# Altium schematic sheet Memory.SchDoc
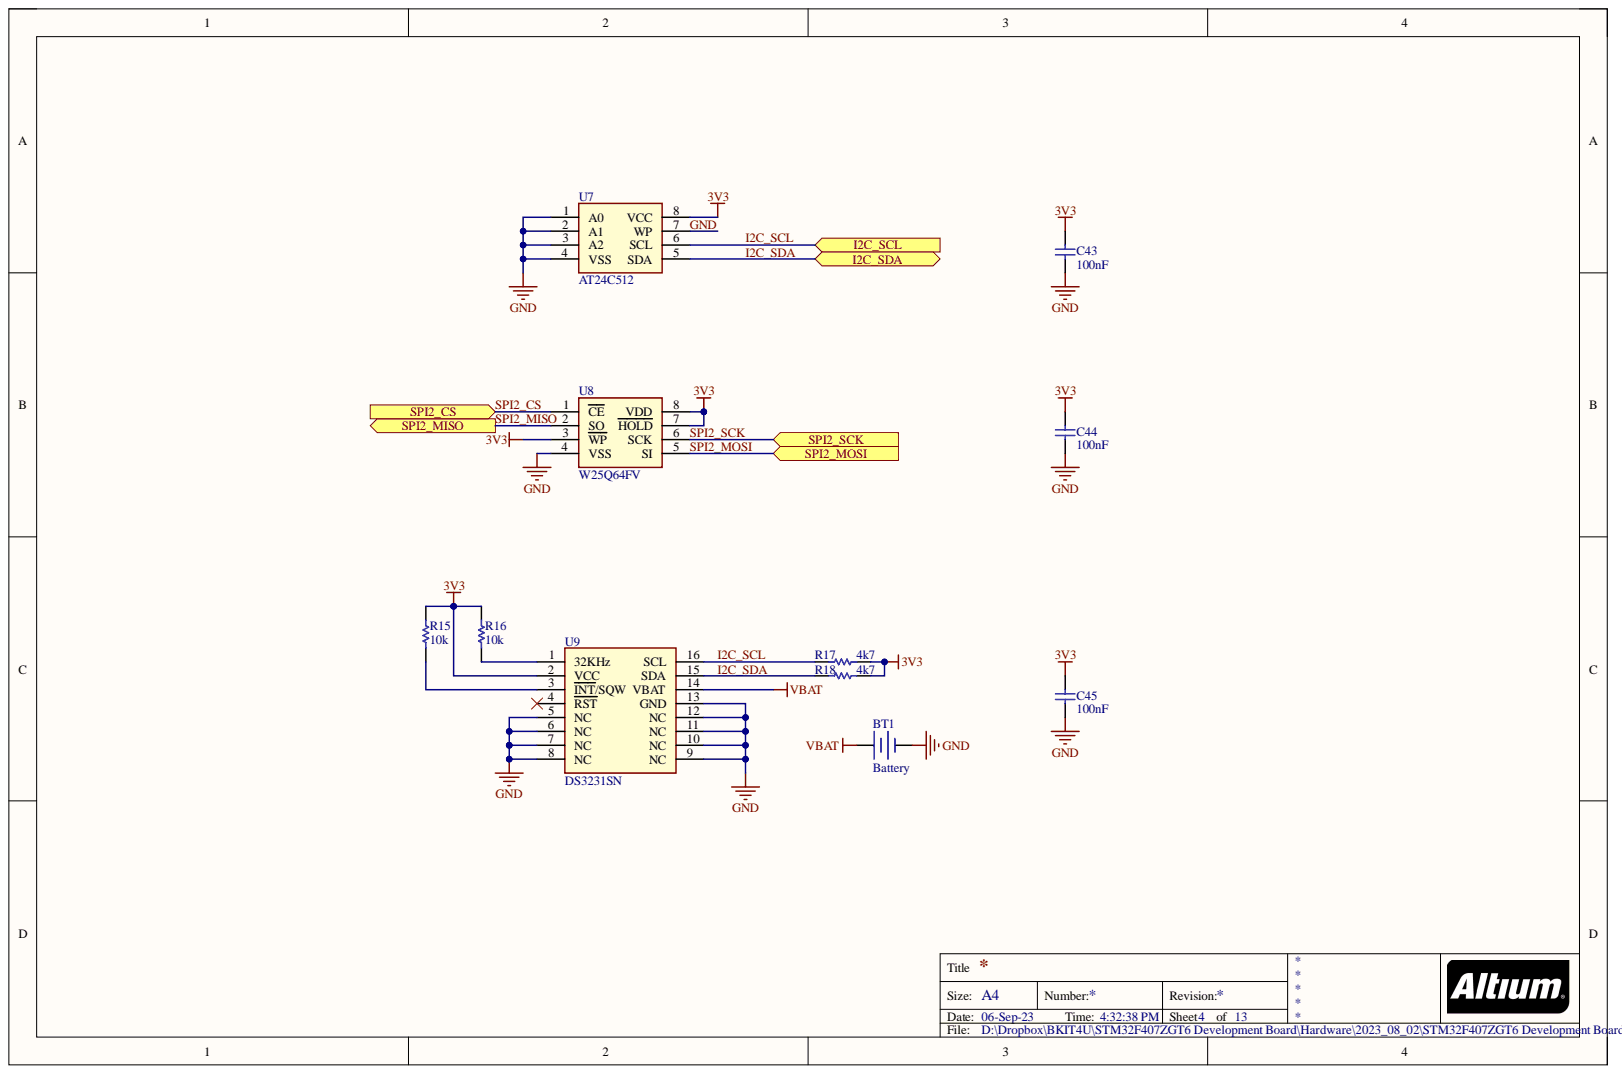
[diagram p0: Memory.SchDoc]

## Parts
BT1  Battery  [CON CR1220]
C43  100nF  [C 0603 (1608)]
C44  100nF  [C 0603 (1608)]
C45  100nF  [C 0603 (1608)]
R15  10k  [R 0603 (1608)]
R16  10k  [R 0603 (1608)]
R17  4k7  [R 0603 (1608)]
R18  4k7  [R 0603 (1608)]
U7  AT24C512  [SOIC-8]
U8  W25Q64FV  [SOIC-8-SM]
U9  DS3231SN  [SOIC-16-WIDE]

## Ports
I2C_SCL, I2C_SDA, SPI2_CS, SPI2_MISO, SPI2_MOSI, SPI2_SCK

## Nets
3V3: C43.1 C44.1 C45.1 R15.2 R16.2 R17.2 R18.2 U7.8(VCC) U8.3(WP) U8.7(HOLD) U8.8(VDD) U9.2(VCC)
VBAT: BT1.1 U9.14(VBAT)
GND: BT1.2 C43.2 C44.2 C45.2 U7.1(A0) U7.2(A1) U7.3(A2) U7.4(VSS) U7.7(WP) U8.4(VSS) U9.5(NC) U9.6(NC) U9.7(NC) U9.8(NC) U9.9(NC) U9.10(NC) U9.11(NC) U9.12(NC) U9.13(GND)
I2C_SCL: R17.1 U7.6(SCL) U9.16(SCL) ; port I2C_SCL
I2C_SDA: R18.1 U7.5(SDA) U9.15(SDA) ; port I2C_SDA
SPI2_CS: U8.1(CE) ; port SPI2_CS
SPI2_MISO: U8.2(SO) ; port SPI2_MISO
SPI2_MOSI: U8.5(SI) ; port SPI2_MOSI
SPI2_SCK: U8.6(SCK) ; port SPI2_SCK
NetR15_1: R15.1 U9.3(INT/SQW)
NetR16_1: R16.1 U9.1(32KHz)

Not connected: U9 4(RST)

## Library data of the parts
C43-C45 = Capacitor NP: Capacitor non-pol; Component Kind: Standard; Library Name: BKIT4U_LIB.SchLib
R15-R18 = Resistor: Component Kind: Standard; Description: Resistor; LatestRevisionDate: 17-Jul-2002; LatestRevisionNote: Re-released for DXP Platform.; Library Name: BKIT4U_LIB.SchLib; PackageReference: AXIAL-0.3; Published: 8-Jun-2000; Publisher: Altium Limited
U7 = 24LCxxx: 2-Wire Serial EEPROM; Component Kind: Standard; Library Name: BKIT4U_LIB.IntLib
U8 = SST25VFXXX: SPI Serial Flash; Component Kind: Standard; Library Name: BKIT4U_LIB.SchLib
U9 = DS3231: Component Kind: Standard; Library Name: BKIT4U_LIB.SchLib
BT1 = Battery: Component Kind: Standard; Library Name: BKIT4U_LIB.SchLib
